# Altium schematic sheet LCM_TEST_V300.SchDoc
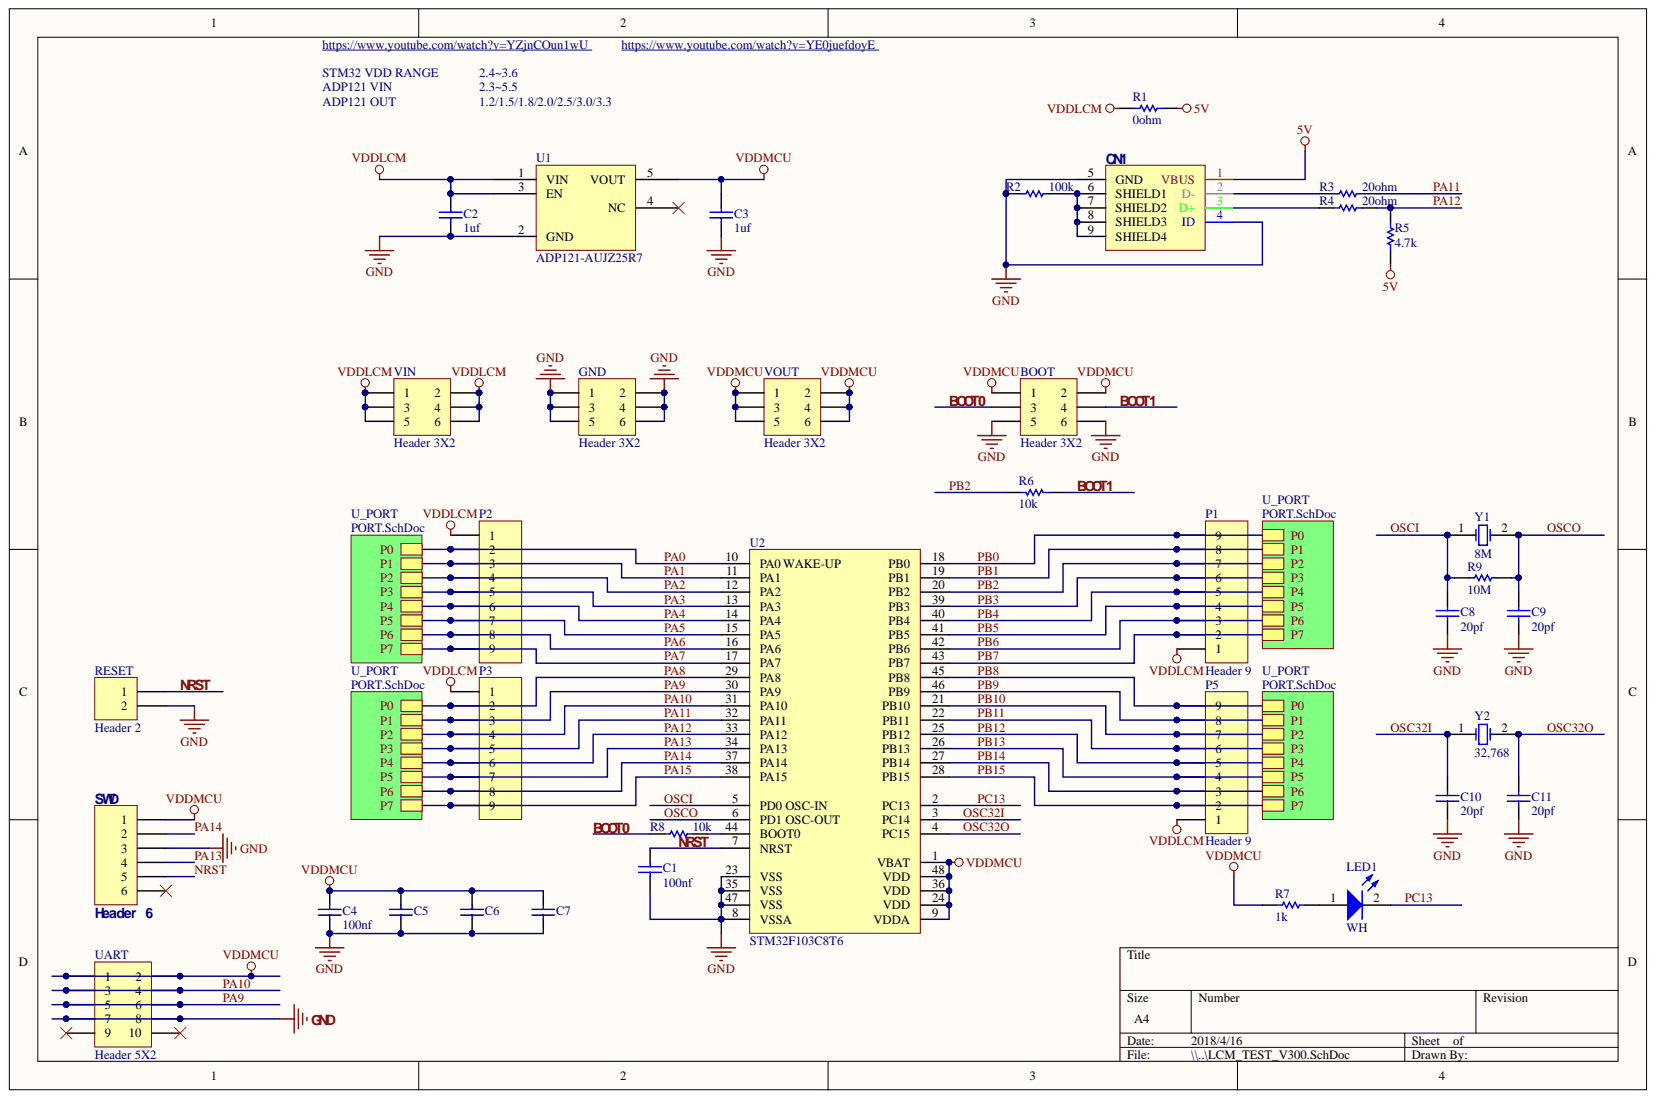
[diagram p0: LCM_TEST_V300.SchDoc]

## Parts
BOOT  Header 3X2  [HDR2X3]
C1  value 100nf  [6-0805_M]  (hidden comment: C104)
C2  value 1uf  [6-0805_M]  (hidden comment: C105)
C3  value 1uf  [6-0805_M]  (hidden comment: C105)
C4  value 100nf  [6-0805_M]  (hidden comment: C104)
C5  C104  [6-0805_M]  (value not drawn)
C6  C104  [6-0805_M]  (value not drawn)
C7  C104  [6-0805_M]  (value not drawn)
C8  value 20pf  [CAP_0603]  (hidden comment: C200)
C9  value 20pf  [CAP_0603]  (hidden comment: C200)
C10  value 20pf  [CAP_0603]  (hidden comment: C200)
C11  value 20pf  [CAP_0603]  (hidden comment: C200)
CN1  USB-Micro-B_TH  [NJ-USB]  (value not drawn)
GND  Header 3X2  [HDR2X3]
LED1  WH  [0603 LED]
P1  Header 9  [HDR1X9]
P2  Header 9  [HDR1X9]  (value not drawn)
P3  Header 9  [HDR1X9]  (value not drawn)
P5  Header 9  [HDR1X9]
R1  value 0ohm  [6-0805_M]  (hidden comment: 0R0)
R2  value 100k  [6-0805_M]  (hidden comment: R104)
R3  value 20ohm  [6-0805_M]  (hidden comment: R200)
R4  value 20ohm  [6-0805_M]  (hidden comment: R200)
R5  value 4.7k  [6-0805_M]  (hidden comment: R472)
R6  value 10k  [6-0805_M]  (hidden comment: R103)
R7  value 1k  [1608[0603]]  (hidden comment: R102)
R8  value 10k  [6-0805_M]  (hidden comment: R103)
R9  value 10M  [6-0805_M]  (hidden comment: R106)
RESET  Header 2  [HDR1X2]
SWD  Header 6  [HDR1X6]
U1  ADP121-AUJZ25R7  [SOT-23-5]
U2  STM32F103C8T6  [LQFP-48_M]
UART  Header 5X2  [HDR2X5]
VIN  Header 3X2  [HDR2X3]
VOUT  Header 3X2  [HDR2X3]
Y1  8M  [HC49]
Y2  32,768  [FC-135]

## Nets
5V: CN1.1(VBUS) R1.2 R5.1
GND: BOOT.5 BOOT.6 C1.1 C2.1 C3.1 C4.2 C5.2 C6.2 C7.2 C8.1 C9.1 C10.1 C11.1 CN1.4(ID) CN1.5(GND) GND.1 GND.2 GND.3 GND.4 GND.5 GND.6 R2.1 RESET.2 SWD.3 U1.2(GND) U2.8(VSSA) U2.23(VSS) U2.35(VSS) U2.47(VSS) UART.7 UART.8
VDDLCM: C2.2 P1.1 P2.1 P3.1 P5.1 R1.1 U1.1(VIN) U1.3(EN) VIN.1 VIN.2 VIN.3 VIN.4 VIN.5 VIN.6
VDDMCU: BOOT.1 BOOT.2 C3.2 C4.1 C5.1 C6.1 C7.1 R7.1 SWD.1 U1.5(VOUT) U2.1(VBAT) U2.9(VDDA) U2.24(VDD) U2.36(VDD) U2.48(VDD) UART.1 UART.2 VOUT.1 VOUT.2 VOUT.3 VOUT.4 VOUT.5 VOUT.6
BOOT0: BOOT.3 R8.1
BOOT1: BOOT.4 R6.1
NRST: C1.2 RESET.1 SWD.5 U2.7(NRST)
OSC32I: C10.2 U2.3(PC14) Y2.1
OSC32O: C11.2 U2.4(PC15) Y2.2
OSCI: C8.2 R9.1 U2.5(PD0_OSC-IN) Y1.1
OSCO: C9.2 R9.2 U2.6(PD1_OSC-OUT) Y1.2
PA0: P2.2 U2.10(PA0_WAKE-UP)
PA1: P2.3 U2.11(PA1)
PA2: P2.4 U2.12(PA2)
PA3: P2.5 U2.13(PA3)
PA4: P2.6 U2.14(PA4)
PA5: P2.7 U2.15(PA5)
PA6: P2.8 U2.16(PA6)
PA7: P2.9 U2.17(PA7)
PA8: P3.2 U2.29(PA8)
PA9: P3.3 U2.30(PA9) UART.5 UART.6
PA10: P3.4 U2.31(PA10) UART.3 UART.4
PA11: P3.5 R3.2 U2.32(PA11)
PA12: P3.6 R4.2 R5.2 U2.33(PA12)
PA13: P3.7 SWD.4 U2.34(PA13)
PA14: P3.8 SWD.2 U2.37(PA14)
PA15: P3.9 U2.38(PA15)
PB0: P1.9 U2.18(PB0)
PB1: P1.8 U2.19(PB1)
PB2: P1.7 R6.2 U2.20(PB2)
PB3: P1.6 U2.39(PB3)
PB4: P1.5 U2.40(PB4)
PB5: P1.4 U2.41(PB5)
PB6: P1.3 U2.42(PB6)
PB7: P1.2 U2.43(PB7)
PB8: P5.9 U2.45(PB8)
PB9: P5.8 U2.46(PB9)
PB10: P5.7 U2.21(PB10)
PB11: P5.6 U2.22(PB11)
PB12: P5.5 U2.25(PB12)
PB13: P5.4 U2.26(PB13)
PB14: P5.3 U2.27(PB14)
PB15: P5.2 U2.28(PB15)
PC13: LED1.2 U2.2(PC13)
NetCN1_2: CN1.2(D-) R3.1
NetCN1_3: CN1.3(D+) R4.1
NetCN1_6: CN1.6(SHIELD1) CN1.7(SHIELD2) CN1.8(SHIELD3) CN1.9(SHIELD4) R2.2
NetLED1_1: LED1.1 R7.2
NetR8_2: R8.2 U2.44(BOOT0)
NetSWD_6: SWD.6
NetU1_4: U1.4(NC)
NetUART_9: UART.9
NetUART_10: UART.10

## Library data of the parts
BOOT GND VIN VOUT = Header 3X2: Header, 3-Pin, Dual row; Component Kind: Standard; LatestRevisionDate: 17-Jul-2002; LatestRevisionNote: Re-released for DXP Platform.; Library Name: Miscellaneous Connectors.IntLib; Signal Integrity: Connector; Publisher: Altium Limited
C1 C4-C7 = C104 0805 50V 10%: 0805/C104,50V,10%,X7R; Prod.Name: 贴片电容,0805/C104,50V,10%,X7R; Prod.NO.: 3672121; Component Kind: Standard; Library Name: INT_LAB_GHOSOFT.IntLib
C2 C3 = C105 0805 50V 10%: 0805/C105,50V,10%,X7R; Prod.Name: 贴片电容,0805/C105,50V,10%,X7R; Prod.NO.: 3672112; Component Kind: Standard; Library Name: INT_LAB_GHOSOFT.IntLib
C8-C11 = C200 0603 50V 5%: 0603/C200,50V,5%,X7R; Prod.Name: 贴片电容,0603/C200,50V,5%,X7R; Prod.NO.: 3672240; Component Kind: Standard; Library Name: INT_LAB_GHOSOFT.IntLib
CN1 = USB-Micro-B_TH: 世连电子 带柱; Prod.Name: CON (MICRO USB 5P SMT WITH POST) (GL55S0); Prod.NO.: 淘宝 郭然然; Component Kind: Standard; Library Name: INT_LAB_GHOSOFT.IntLib
LED1 = LED 0603 WH: Prod.Name: LED贴片灯LT-0603WH(659-725MCD,2.9-3.1V)113BI,BK档; Prod.NO.: 3923403; Component Kind: Standard; Library Name: INT_LAB_GHOSOFT.IntLib
P1-P3 P5 = Header 9: Header, 9-Pin; Component Kind: Standard; LatestRevisionDate: 17-Jul-2002; LatestRevisionNote: Re-released for DXP Platform.; Library Name: Miscellaneous Connectors.IntLib; Signal Integrity: Connector; Publisher: Altium Limited
R1 = R000 0R0 0805 5%: Prod.Name: 电阻,0805/R000,5%; Prod.NO.: 3671015; Component Kind: Standard; Description: R000 0R0 0805 5%; Library Name: INT_LAB_GHOSOFT.IntLib
R2 = R104 0805 5%: 0805/R104,5%; Prod.Name: 电阻,0805/R104,5%; Prod.NO.: 3671214; Component Kind: Standard; Library Name: INT_LAB_GHOSOFT.IntLib
R3 R4 = R200 0805 5%: 0805/R200,5%; Prod.Name: 电阻,0805/R200,5%; Prod.NO.: 3671273; Component Kind: Standard; Library Name: INT_LAB_GHOSOFT.IntLib
R5 = R472 0805 5%: 0805/R472,5%; Prod.Name: 电阻,0805/R472,5%; Prod.NO.: 3671074; Component Kind: Standard; Library Name: INT_LAB_GHOSOFT.IntLib
R6 R8 = R103 0805 5%: 0805/R103,5%; Prod.Name: 电阻,0805/R103,5%; Prod.NO.: 3671020; Component Kind: Standard; Library Name: INT_LAB_GHOSOFT.IntLib
R7 = R102 0603 5%: 0603/R102,5%; Prod.Name: 电阻,0603/R102,5%; Prod.NO.: 3671397; Component Kind: Standard; Library Name: INT_LAB_GHOSOFT.IntLib
R9 = R106 0805 5%: 0805/R106,5%; Prod.Name: 电阻,0805/R106,5%; Prod.NO.: 3671350; Component Kind: Standard; Library Name: INT_LAB_GHOSOFT.IntLib
RESET = Header 2: Header, 2-Pin; Component Kind: Standard; LatestRevisionDate: 17-Jul-2002; LatestRevisionNote: Re-released for DXP Platform.; Library Name: Miscellaneous Connectors.IntLib; Signal Integrity: Connector; Publisher: Altium Limited
SWD = Header 6: Header, 6-Pin; Component Kind: Standard; LatestRevisionDate: 17-Jul-2002; LatestRevisionNote: Re-released for DXP Platform.; Library Name: Miscellaneous Connectors.IntLib; Signal Integrity: Connector; Publisher: Altium Limited
U2 = STM32F103C8T6: Medium-density performance line ARM?-based 32-bit MCU with 64  or 128 KB Flash, USB, CAN, 7 timers, 2 ADCs, 9 com. interfaces; Prod.Name: SMT IC,STM32F103C8T6; Prod.NO.: 4644546; Component Kind: Standard; Library Name: INT_LAB_GHOSOFT.IntLib
UART = Header 5X2: Header, 5-Pin, Dual row; Component Kind: Standard; LatestRevisionDate: 17-Jul-2002; LatestRevisionNote: Re-released for DXP Platform.; Library Name: Miscellaneous Connectors.IntLib; Signal Integrity: Connector; Publisher: Altium Limited
Y1 = XTAL 8M: 8M 插脚; Prod.Name: 石英插脚晶振,8M/HC-49/S; Prod.NO.: 3674020; Component Kind: Standard; Library Name: INT_LAB_GHOSOFT.IntLib
Y2 = XTAL 32768_1: 贴片晶振 FC-135/32768HZ; Prod.NO.: 3674049; Component Kind: Standard; Prod.Name: 贴片晶振 FC-135/32768HZ; Library Name: INT_LAB_GHOSOFT.IntLib
U1 = ADP121-AUJZ25R7: Component Kind: Standard; Description: ADP121-AUJZ25R7; Library Name: INT_LAB_GHOSOFT.IntLib
